# Altium schematic sheet Power.SchDoc
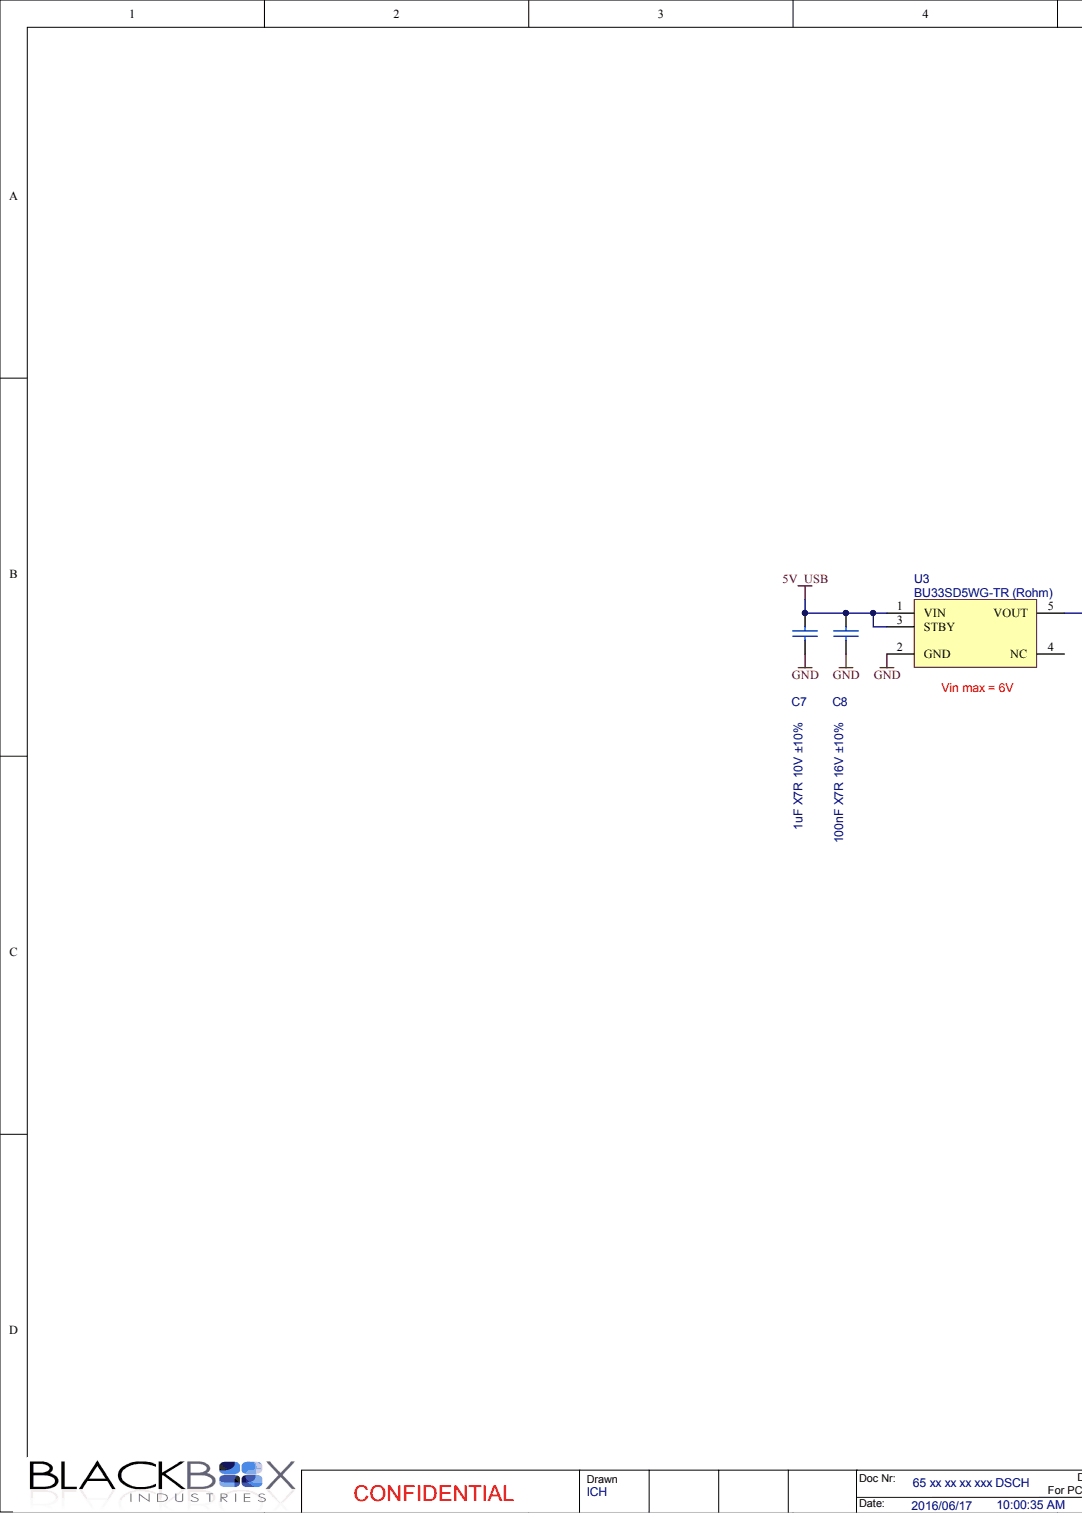
[diagram p0: Power.SchDoc, left]
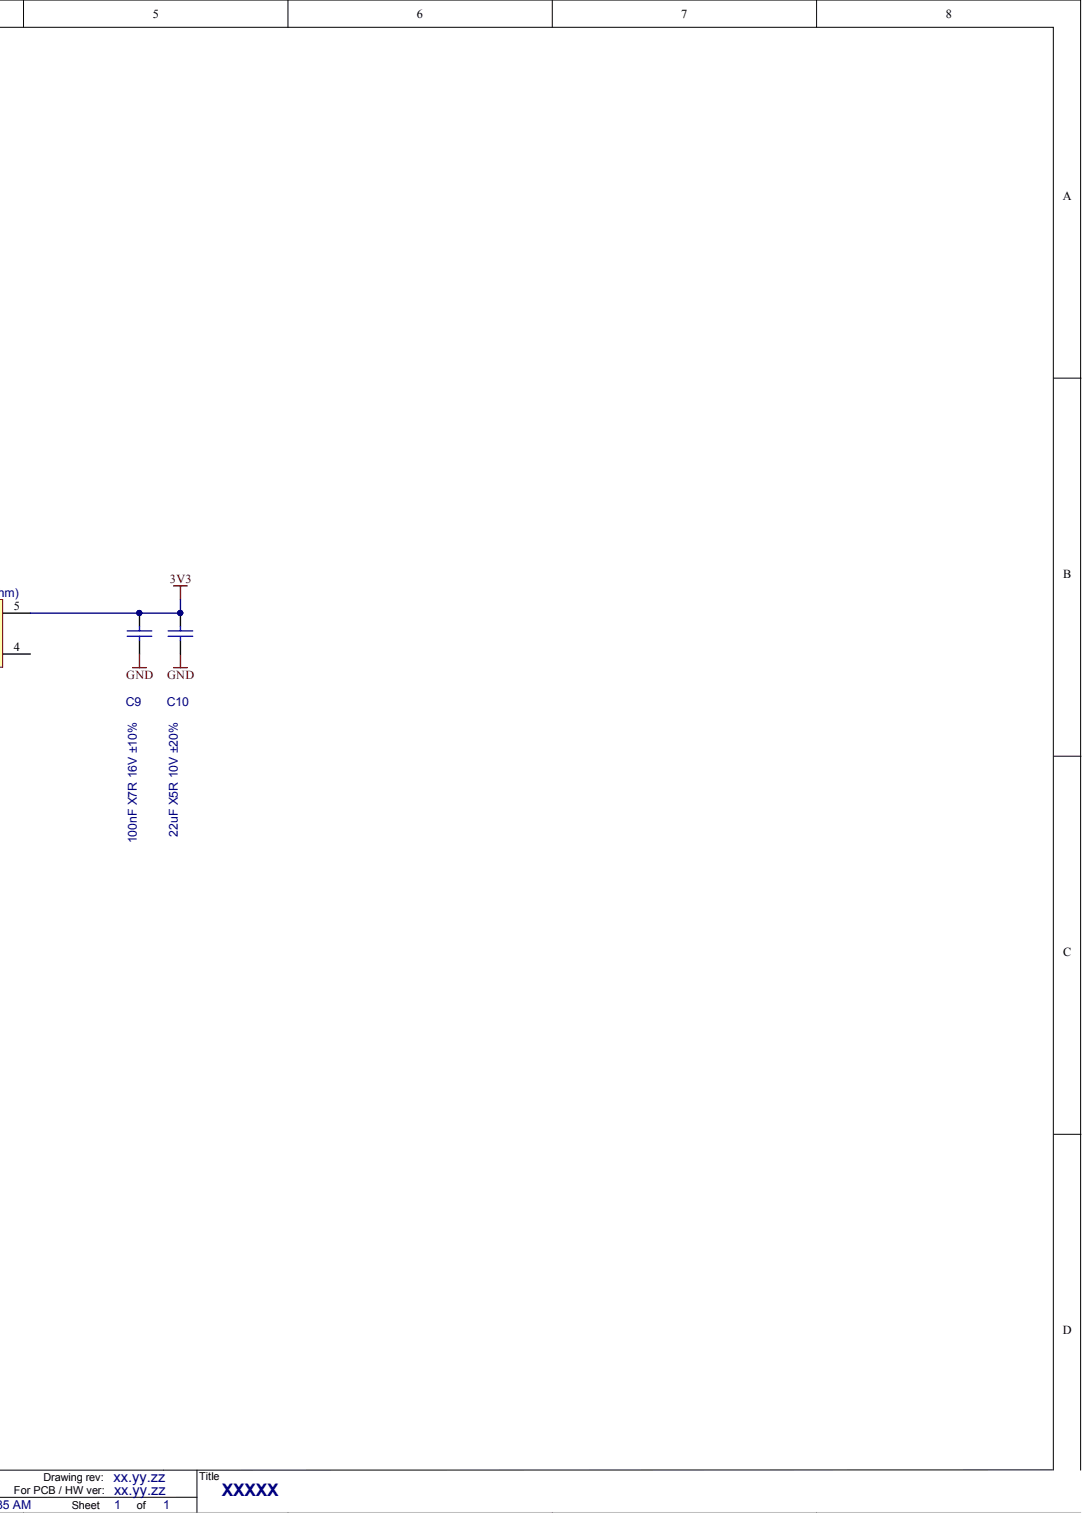
[diagram p1: Power.SchDoc, right]

## Parts
C7  1uF X7R 10V ±10%  [0603_CAP]
C8  100nF X7R 16V ±10%  [0603_CAP]
C9  100nF X7R 16V ±10%  [0603_CAP]
C10  22uF X5R 10V ±20%  [0603_CAP]
U3  BU33SD5WG-TR (Rohm)  [SOT-23-5]

## Nets
3V3: C9.1 C10.1 U3.5(VOUT)
5V_USB: C7.1 C8.1 U3.1(VIN) U3.3(STBY)
GND: C7.2 C8.2 C9.2 C10.2 U3.2(GND)

Not connected: U3 4(NC)

## Library data of the parts
C7 = 1uF X7R 10V ±10%: Capacitor, ceramic; Component Kind: Standard; descr: Capacitor, ceramic; Library Name: OneCell passives.IntLib; Supplier 1: Digi-Key; Supplier Part Number 1: 587-1242-2-ND
C8 C9 = 100nF X7R 16V ±10%: Capacitor, ceramic; Component Kind: Standard; descr: Capacitor, ceramic; Library Name: OneCell passives.IntLib; Supplier 1: Digi-Key; Supplier Part Number 1: 490-1532-2-ND
C10 = 22uF X5R 10V ±20%: Capacitor, ceramic 0603; Component Kind: Standard; descr: Capacitor, ceramic 0603; Supplier 1: Digi-Key; Supplier Part Number 1: 445-9077-1-ND; Library Name: Onecell Ig.IntLib
U3 = BU33SD5WG-TR (Rohm): LDO 3.3V 500mA SOT-23-5; Component Kind: Standard; descr: LDO 3.3V 500mA SOT-23-5; Supplier 1: Digi-Key; Supplier Part Number 1: BU33SD5WG-TRCT-ND; Library Name: Onecell Ig.IntLib
